# Altium schematic sheet 13_Power.SchDoc
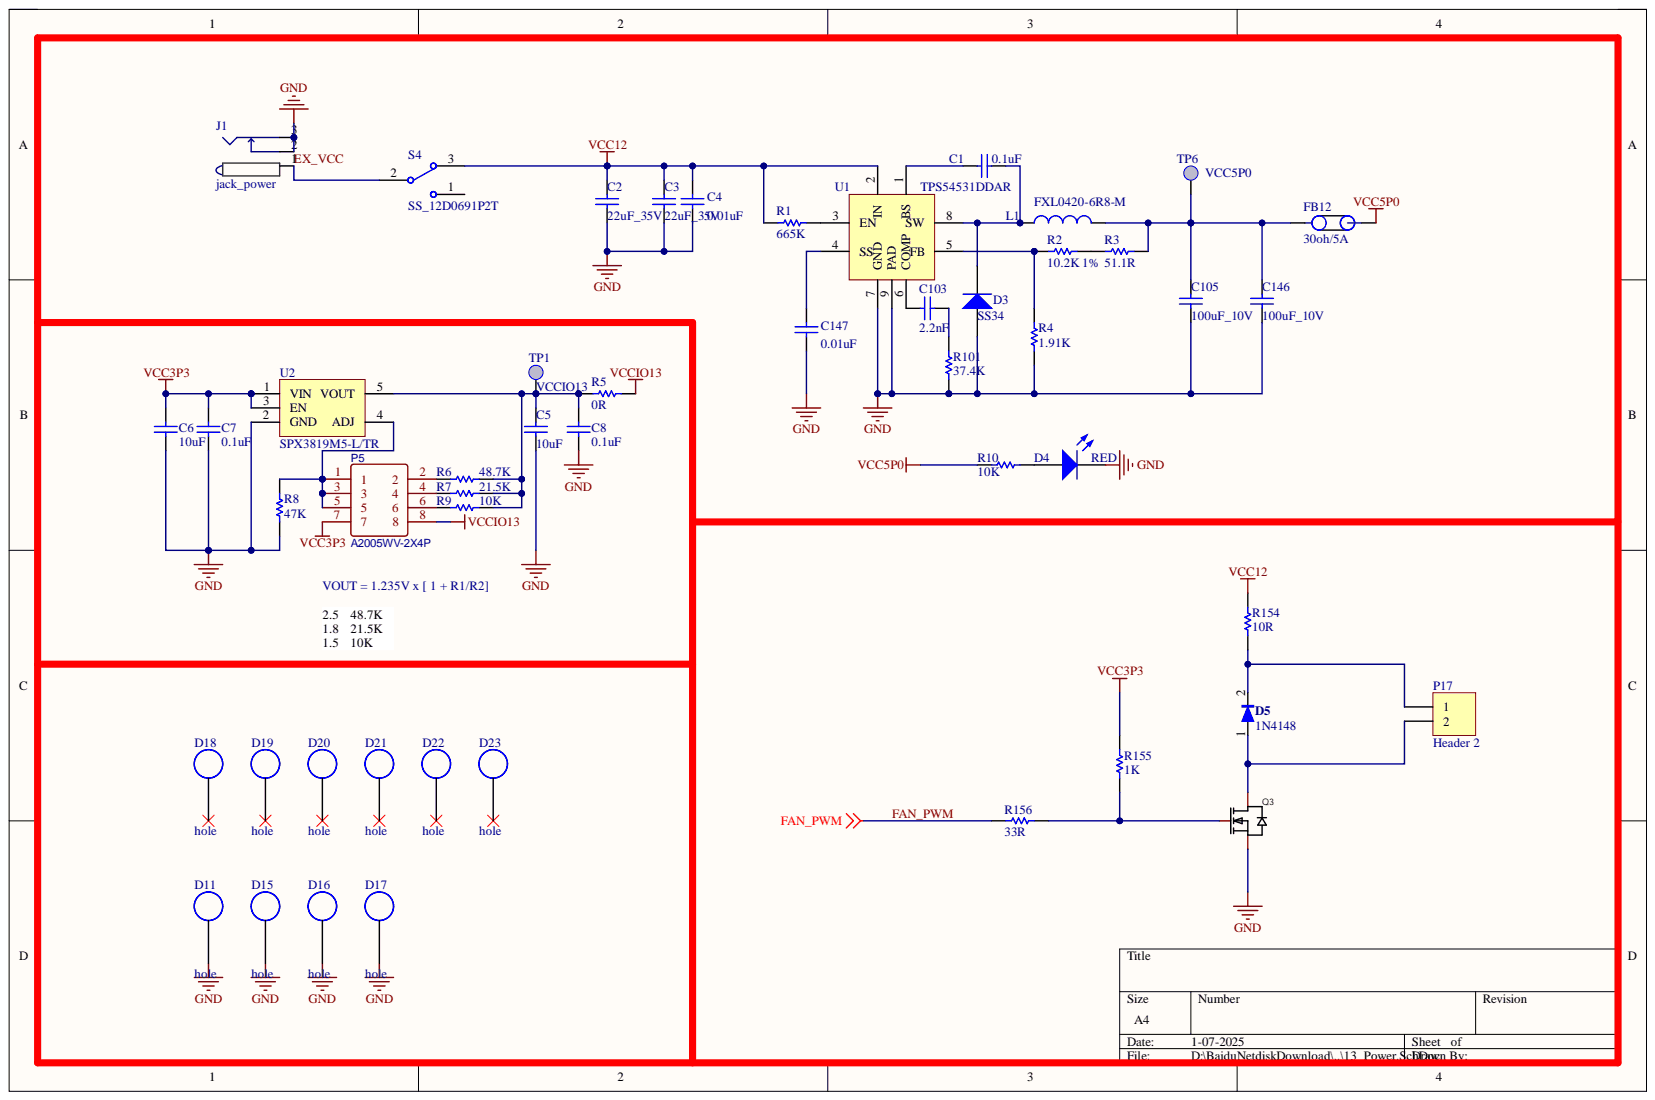
[diagram p0: 13_Power.SchDoc]

## Parts
C1  0.1uF  [0603C]
C2  22uF_35V  [C1206]
C3  22uF_35V  [C1206]
C4  0.01uF  [0603C]
C5  10uF  [C0805]
C6  10uF  [C0805]
C7  0.1uF  [C0603]
C8  0.1uF  [C0603]
C103  2.2nF  [0603C]
C105  100uF_10V  [C1206]
C146  100uF_10V  [C1206]
C147  0.01uF  [0603C]
D3  SS34  [DO-214AC]
D4  RED  [0603_LED]
D5  value 1N4148  [SOD-123]  (hidden comment: DIODE1)
D11  hole  [HOLE4MM2R7MM]
D15  hole  [HOLE4MM2R7MM]
D16  hole  [HOLE4MM2R7MM]
D17  hole  [HOLE4MM2R7MM]
D18  hole  [HOLE5R715MM3R175MM-8STAR]
D19  hole  [HOLE5R715MM3R175MM-8STAR]
D20  hole  [HOLE5R715MM3R175MM-8STAR]
D21  hole  [HOLE5R715MM3R175MM-8STAR]
D22  hole  [HOLE5R715MM3R175MM-8STAR]
D23  hole  [HOLE5R715MM3R175MM-8STAR]
FB12  30oh/5A  [L1206]
J1  jack_power  [DS-0205-2_0]
L1  FXL0420-6R8-M  [IND-SMD_L4_0-W4_0]
P5  A2005WV-2X4P  [hdr-th_8p-p2_00-v-m-r2-c4-s2_00]
P17  Header 2  [conn-th_2p-p2_50_hc-xh-2a-g]
Q3  NDS331N_C192741  [SOT-23-3]  (value not drawn)
R1  665K  [0603R]
R2  10.2K 1%  [0603R]
R3  51.1R  [0603R]
R4  1.91K  [0603R]
R5  0R  [R0603]
R6  48.7K  [0603R]
R7  21.5K  [0603R]
R8  47K  [0603R]
R9  10K  [0603R]
R10  10K  [0603R]
R101  37.4K  [0603R]
R154  10R  [R0603]
R155  1K  [R0603]
R156  33R  [R0603]
S4  SS_12D0691P2T  [3PINSWITCH]
TP1  VCCIO13  [tp32]
TP6  VCC5P0  [tp32]
U1  TPS54531DDAR  [SOIC8-EP]
U2  SPX3819M5-L/TR  [SOT23-5]

## Nets
GND: C2.2 C3.2 C4.1 C5.1 C6.1 C7.1 C8.1 C105.1 C146.1 C147.1 D3.1 D4.2 D11.1 D15.1 D16.1 D17.1 J1.2 J1.3 Q3.2 R4.1 R8.1 R101.2 U1.7(GND) U1.9(PAD) U2.2(GND)
VCC3P3: C6.2 C7.2 P5.7 R155.1 U2.1(VIN) U2.3(EN)
VCC5P0: FB12.2 R10.1
VCC12: C2.1 C3.1 C4.2 R1.1 R154.2 S4.3 U1.2(IN)
VCCIO13: P5.8 R5.1
EX_VCC: J1.1 S4.2
FAN_PWM: R156.2
NetC1_1: C1.1 D3.2 L1.1 U1.8(SW)
NetC1_2: C1.2 U1.1(BS)
NetC5_2: C5.2 C8.2 R5.2 R6.2 R7.2 R9.2 TP1.1 U2.5(VOUT)
NetC103_1: C103.1 R101.1
NetC103_2: C103.2 U1.6(COMP)
NetC105_2: C105.2 C146.2 FB12.1 L1.2 R3.2 TP6.1
NetC147_2: C147.2 U1.4(SS)
NetD4_1: D4.1 R10.2
NetD5_1: D5.1 P17.2 Q3.3
NetD5_2: D5.2 P17.1 R154.1
NetP5_1: P5.1 P5.3 P5.5 R8.2 U2.4(ADJ)
NetP5_2: P5.2 R6.1
NetP5_4: P5.4 R7.1
NetP5_6: P5.6 R9.1
NetQ3_1: Q3.1 R155.2 R156.1
NetR1_2: R1.2 U1.3(EN)
NetR2_1: R2.1 R4.2 U1.5(FB)
NetR2_2: R2.2 R3.1

Not connected: D18 1; D19 1; D20 1; D21 1; D22 1; D23 1; S4 1

## Library data of the parts
C1-C8 C103 C105 C146 C147 = Cap: Capacitor; Component Kind: Standard; LatestRevisionDate: 17-Jul-2002; LatestRevisionNote: Re-released for DXP Platform.; Library Name: Miscellaneous Devices.IntLib; PackageDescription: Radial Cap, Thru-Hole; 2 Leads; 0.3 in Pin Spacing; PackageReference: RAD-0.3; Published: 8-Jun-2000; Signal Integrity: Cap; Simulation: CAP; Publisher: Altium Limited
  C1: Value: 0.1uf
  C2 C3: Value: 22uF_35V
  C4 C147: Value: 0.01uf
  C5 C6: Value: 10uF
  C7 C8: Value: 0.1uF
  C103: Value: 2.2nF
  C105 C146: Value: 100uF_10V
D3 = Diode: Default Diode; Code_JEDEC: DO-214-AB; Component Kind: Standard; LatestRevisionDate: 17-Jul-2002; LatestRevisionNote: Re-released for DXP Platform.; Library Name: Miscellaneous Devices.IntLib; PackageDescription: DO-214-AB/SMC; 2 C-Bend Leads; Body 7.9 x 5.9 mm, inc. leads (LxW); PackageReference: SMA; PackageVersion: Sep-1996; Published: 8-Jun-2000; Publisher: Altium Limited
D4 = LED2: Typical RED, GREEN, YELLOW, AMBER GaAs LED; Component Kind: Standard; LatestRevisionDate: 17-Jul-2002; LatestRevisionNote: Re-released for DXP Platform.; Library Name: Miscellaneous Devices.IntLib; PackageDescription: SM LED; 2 Flat Leads; PackageReference: 3.2X1.6X1.1; PackageVersion: Feb-2002; Published: 8-Jun-2000; Value: RED; Publisher: Altium Limited
D5 = (no symbol name): 1N4148,SOD-323,RoHS; 名称: 二极管; 物料代码: 1.04.07.0027; 设备封装名称: SOD-323_1N4148; 贴片和直插: SMT; Component Kind: Standard; ComponentLink1Description: ErpDataInfo; ComponentLink1URL: http://erp.zlgmcu.com/ErpExt/ErpData/ListMateriel.aspx?pkey=1.04.07.0027; Library Name: zynq.SCHLIB; RoHS: RoHS; 型号: 1N4148; 封装: SOD-323
FB12 = CiZhu: Component Kind: Standard; Library Name: user.SchLib; Value: 30oh/5A
J1 = jack_pwr: Component Kind: Standard; Description: jack_pwr; Library Name: zynq.SCHLIB; Value: jack_power
L1 = Inductor: Code_IEC: 1005; Code_JEITA: 0402; Component Kind: Standard; Description: Inductor; LatestRevisionDate: 29-May-2009; LatestRevisionNote: IPC-7351 Footprint Added.; Library Name: Miscellaneous Devices.IntLib; PackageDescription: Chip Inductor; PackageReference: 0402-A; Published: 8-Jun-2000; Value: FXL0420-6R8-M; Publisher: Altium Limited
P5 = A2005WV-2X4P: 2.0MM   2*4; BOM_Manufacturer: Changjiang Connectors; Contributor: LCSC; BOM_JLCPCB Part Class: Extended Part; BOM_Manufacturer Part: A2005WV-2x4P; BOM_Supplier: LCSC; BOM_Supplier Part: C225289; Component Kind: Standard; Library Name: zynq.SCHLIB; spicePre: C; spiceSymbolName: A2005WV-2X4P
P17 = Header 2: Header, 2-Pin; Component Kind: Standard; LatestRevisionDate: 17-Jul-2002; LatestRevisionNote: Re-released for DXP Platform.; Library Name: Miscellaneous Connectors.IntLib; Signal Integrity: Connector; Publisher: Altium Limited
Q3 = NDS331N_C192741: Manufacturer: onsemi(安森美); Add into BOM: yes; Continuous Drain Current (Id): 1.3A; Drain Source On Resistance (RDS(on)@Vgs,Id): 160mΩ@4.5V,1.5A; Drain Source Voltage (Vdss): 20V; Gate Threshold Voltage (Vgs(th)@Id): 1V@250uA; Power Dissipation (Pd): 500mW; Type: N沟道; Component Kind: Standard; Convert to PCB: yes; Datasheet: https://atta.szlcsc.com/upload/public/pdf/source/20190308/C192741_2505D141476AAC5237D6D010026566FE.pdf; Device: NDS331N_C192741; JLCPCB Part Class: Extended Part; LCSC Part Name: NDS331N; Manufacturer Part: NDS331N; Name: NDS331N; PCB3D: SOT-23-3P_L2.9-W1.3-H1.0-LS2.4-P0.95; Supplier: LCSC; Supplier Footprint: SOT-23; Supplier Part: C192741; Symbol: NDS331N
R1-R10 R101 R154-R156 = Res1: Resistor; Component Kind: Standard; LatestRevisionDate: 17-Jul-2002; LatestRevisionNote: Re-released for DXP Platform.; Library Name: Miscellaneous Devices.IntLib; PackageDescription: Axial Device, Thru-Hole; 2 Leads; 0.3 in Pin Spacing; PackageReference: AXIAL-0.3; Published: 8-Jun-2000; Signal Integrity: Res; Simulation: RESISTOR; Publisher: Altium Limited
  R1: Value: 665K
  R2: Value: 10.2K 1%
  R3: Value: 51.1R
  R4: Value: 1.91K
  R5: Value: 0R
  R6: Value: 48.7K
  R7: Value: 21.5K
  R8: Value: 47K
  R9 R10: Value: 10K
  R101: Value: 37.4K
  R154: Value: 10R
  R155: Value: 1K
  R156: Value: 33R
S4 = SW-SPDT: SPDT Subminiature Toggle Switch, Right Angle Mounting, Vertical Actuation; Component Kind: Standard; ComponentLink1Description: Manufacturer Link; ComponentLink1URL: http://www.apem.com; DatasheetVersion: 05-Dec-2000; LatestRevisionDate: 17-Dec-2003; LatestRevisionNote: Schematic revised, PCB Footprint replaced.; Library Name: zynq.SCHLIB; PackageReference: TL36WW15050; Published: 24-Mar-1999; Publisher: Altium Limited
TP1 = TestPoint: Component Kind: Standard; Library Name: USB302.Lib
TP6 = TestPoint: 测试点; Component Kind: Standard; Library Name: USB302.Lib
U1 = MP1484EN: Component Kind: Standard; Library Name: xiaomeige_fpga.SCHLIB
U2 = SPX3819: Component Kind: Standard; Library Name: ACX730.SCHLIB
D11 D15-D23 = hole: Component Kind: Standard; Description: hole; Library Name: Schlib1.SchLib
